# Altium schematic sheet [01] - SiPM Carrier.SchDoc("[01] - SiPM Carrier")
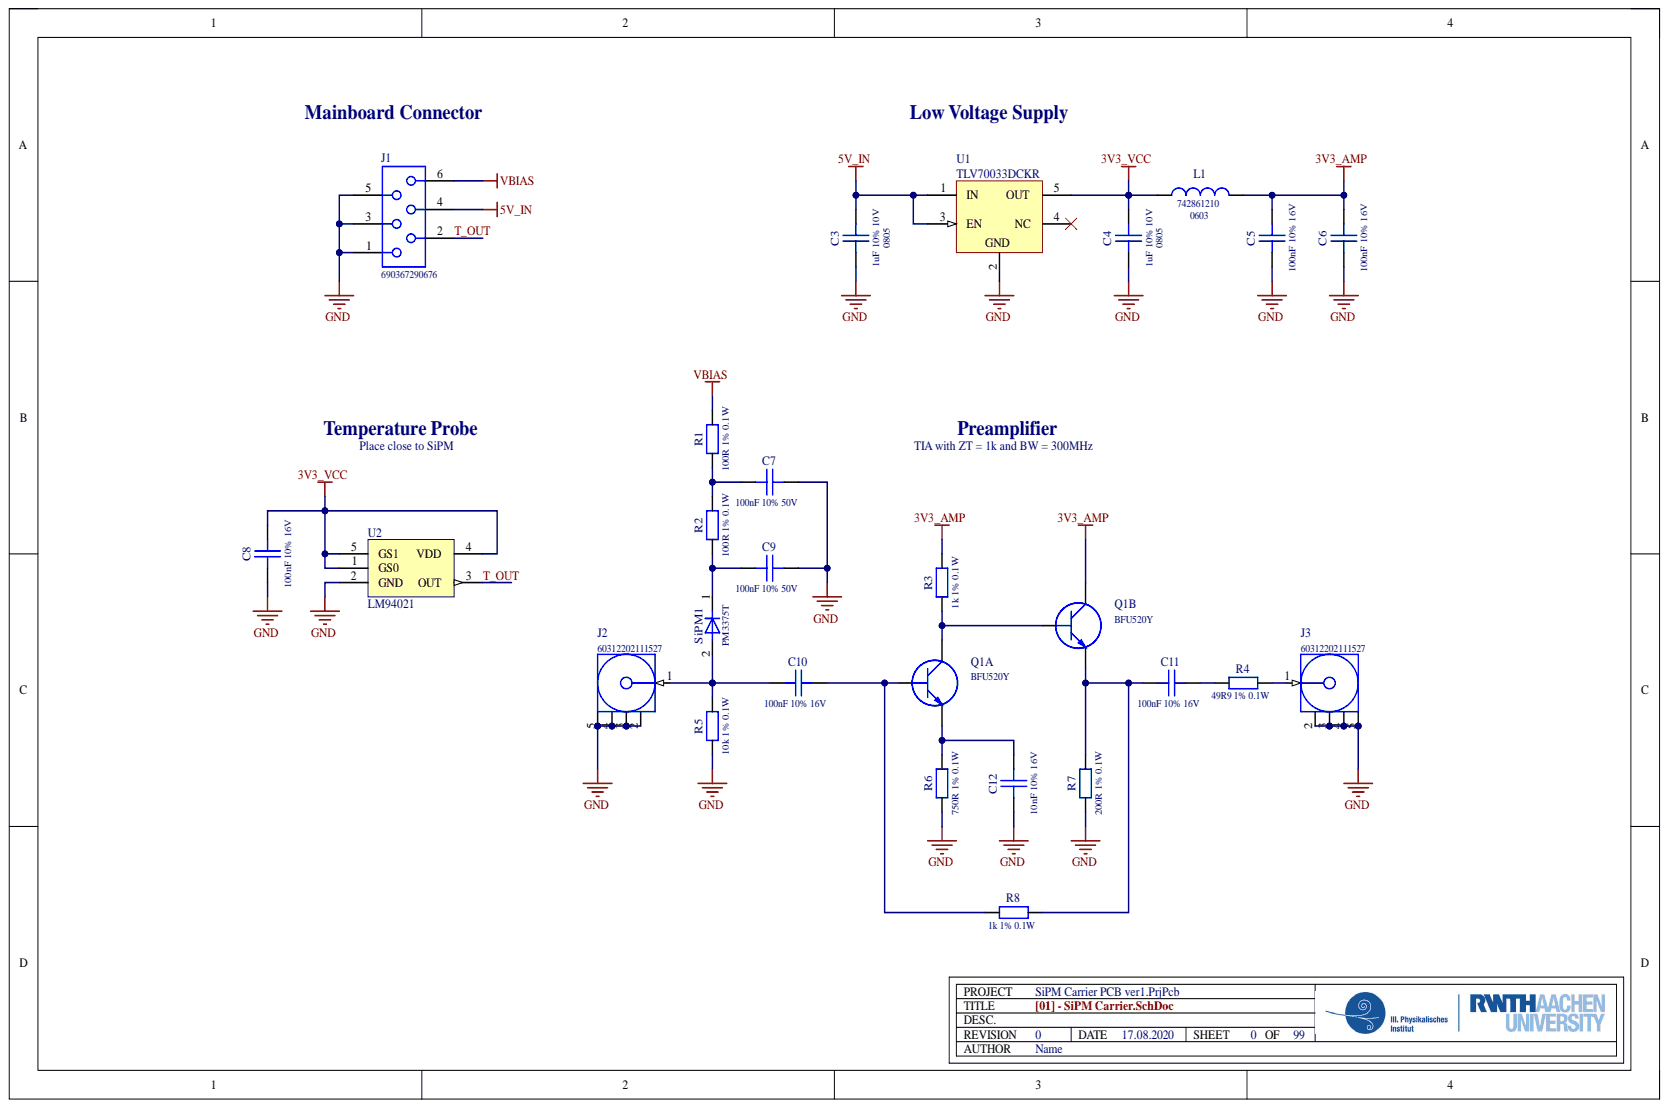
[diagram p0: [01] - SiPM Carrier.SchDoc]

## Parts
C3  1uF 10% 10V  PackageReference: 0805  [0805_CAP]
C4  1uF 10% 10V  PackageReference: 0805  [0805_CAP]
C5  100nF 10% 16V  [0603_CAP]
C6  100nF 10% 16V  [0603_CAP]
C7  100nF 10% 50V  [0603_CAP]
C8  100nF 10% 16V  [0603_CAP]
C9  100nF 10% 50V  [0603_CAP]
C10  100nF 10% 16V  [0603_CAP]
C11  100nF 10% 16V  [0603_CAP]
C12  10nF 10% 16V  [0603_CAP]
J1  690367290676  [690367290676]
J2  60312202111527  [60312202111527]
J3  60312202111527  [60312202111527]
L1  742861210  PackageReference: 0603  [0603_RES]
Q1  BFU520Y  [SOT363-6]  (parts A,B)
R1  100R 1% 0.1W  [0603_RES]
R2  100R 1% 0.1W  [0603_RES]
R3  1k 1% 0.1W  [0603_RES]
R4  49R9 1% 0.1W  [0603_RES]
R5  10k 1% 0.1W  [0603_RES]
R6  750R 1% 0.1W  [0603_RES]
R7  200R 1% 0.1W  [0603_RES]
R8  1k 1% 0.1W  [0603_RES]
SiPM1  PM3375T  [PM3375T_SMD]
U1  TLV70033DCKR  [TI-DCK5_V]
U2  LM94021  [SC70]

## Nets
3V3_AMP: C5.2 C6.2 L1.2 Q1.3 R3.1
3V3_VCC: C4.2 C8.2 L1.1 U1.5(OUT) U2.1(GS0) U2.4(VDD) U2.5(GS1)
5V_IN: C3.2 J1.4 U1.1(IN) U1.3(EN)
GND: C3.1 C4.1 C5.1 C6.1 C7.2 C8.1 C9.2 C12.1 J1.1 J1.3 J1.5 J2.2 J2.3 J2.4 J2.5 J3.2 J3.3 J3.4 J3.5 R5.2 R6.2 R7.2 U1.2 U2.2(GND)
VBIAS: J1.6 R1.1
T_OUT: J1.2 U2.3(OUT)
NetC7_1: C7.1 R1.2 R2.1
NetC9_1: C9.1 R2.2 SiPM1.1
NetC10_1: C10.1 J2.1 R5.1 SiPM1.2
NetC10_2: C10.2 Q1.1 R8.2
NetC11_1: C11.1 Q1.5 R7.1 R8.1
NetC11_2: C11.2 R4.2
NetC12_2: C12.2 Q1.2 R6.1
NetJ3_1: J3.1 R4.1
NetQ1_4: Q1.4 Q1.6 R3.2

Not connected: U1 4(NC)

## Library data of the parts
C3-C12 = Capacitor: Simple generic capacitor.; Component Kind: Standard; Library Name: CustomComponents.IntLib
  C3 C4: Value: 1uF 10% 10V
  C5 C6 C8 C10 C11: PackageReference: 0603; Value: 100nF 10% 16V
  C7 C9: PackageReference: 0603; Value: 100nF 10% 50V
  C12: PackageReference: 0603; Value: 10nF 10% 16V
J1 = 690367290676: WR-MM MiniModule Connector, Female, SMT, Vertical, pitch 2.54mm, 6pins; Contact Resistance: 10mOhm; Insulation Resistance: >1000MOhm; Pin Number: 6; Rated Current: 1.5A; Withstanding Voltage: 500VAC; Working Voltage: 100VAC; Component Kind: Standard; ComponentLink1Description: Manufacturer; ComponentLink1URL: http://www.we-online.de; ComponentLink2Description: Datasheet; ComponentLink2URL: https://katalog.we-online.de/em/datasheet/690367290676.pdf; Gender: Female; Library Name: WR-MM.IntLib; Mounting Technology: SMT; Operating Temperature: -40 to +105degC; Orientation: Vertical; Packaging: Tape and Reel; Part Number: 690367290676; Pitch: 2.54mm; Published Date: June 2019; Publisher: Wurth Elektronik
J2 J3 = 60312202111527: WR-SMA PCB End Launch Jack Round Post for 1.6mm PCB, SMT, Straight; Center Contact Diameter: 0.76mm; Connector Type: SMA; Frequency Range: DC~18GHz; Impedance: 50Ohm; Interface: MIL-STD-348; Component Kind: Standard; ComponentLink1Description: Manufacturer; ComponentLink1URL: http://www.we-online.de; ComponentLink2Description: Datasheet; ComponentLink2URL: https://katalog.we-online.com/em/datasheet/60312202111527.pdf; Gender: Jack; Library Name: WR-SMA.IntLib; Mounting Technology: SMT; Operating Temperature: -65 to +165degC; Orientation Type: Straight; Packaging: Tray; Part Number: 60312202111527; Published Date: May 2019; Publisher: Wurth Elektronik
L1 = Inductor: Simple generic inductor.; PartNumber: XXX; Component Kind: Standard; Library Name: CustomComponents.IntLib; Value: 742861210
Q1 = BFU520Y: Dual NPN wideband silicon RF transistor.; Component Kind: Standard; Library Name: CustomComponents.IntLib; PackageReference: SOT363-6; Value: BFU520Y
R1-R8 = Resistor: Simple generic resistor.; Component Kind: Standard; Library Name: CustomComponents.IntLib; PackageReference: 0603
  R1 R2: Value: 100R 1% 0.1W
  R3 R8: Value: 1k 1% 0.1W
  R4: Value: 49R9 1% 0.1W
  R5: Value: 10k 1% 0.1W
  R6: Value: 750R 1% 0.1W
  R7: Value: 200R 1% 0.1W
SiPM1 = PM3375T: Silicon Photomultiplier, 3x3mm2 - 75um type - trench - SMD housing; Component Kind: Standard; Library Name: CustomComponents.IntLib; Value: PM3375T
U1 = TLV70033DCKR: Single Output LDO, 200 mA, Fixed 3.3 V Output, 2 to 5.5 V Input, with Low IQ, 5-pin SC70 (DCK), -40 to 125 degC, Green (RoHS & no Sb/Br); Manufacturer: Texas Instruments; Accuracy(%): 2; Fixed Output Options(V): 3.3; Iout(Max)(A): 0.2; Iq(Typ)(mA): 0.03; SubFamily: Single Channel LDO; Vdo(Typ)(mV): 175; Vin(Max)(V): 5.5; Code_JEDEC: MO-203; Component Kind: Standard; ComponentLink1Description: Manufacturer URL; ComponentLink1URL: http://www.ti.com/; ComponentLink2Description: Datasheet; ComponentLink2URL: http://www.ti.com/general/docs/lit/getliterature.tsp?genericPartNumber=TLV70033&fileType=pdf; ComponentLink3Description: Package Specification; ComponentLink3URL: http://www.ti.com/litv/pdf/mpds025f; DatasheetVersion: SLVSA00D; Eco-Plan: Green (RoHS & no Sb/Br); Library Name: TI Power Management Linear Regulator Single Channel LDO.IntLib; Mounting Technology: Surface Mount; PackageDescription: 5-Pin Plastic Small-Outline, Body 2 x 1.25 mm, Pitch 0.65 mm; PackageReference: DCK5; PackageVersion: 4093553-3/G; PartNumber: TLV70033DCKR; Supplier 1: Digi-Key; Supplier 2: Digi-Key; Supplier 3: Digi-Key; Supplier 4: Newark; Supplier 5: Mouser; Supplier Part Number 1: 296-32413-2-ND; Supplier Part Number 2: 296-32413-1-ND; Supplier Part Number 3: 296-32413-6-ND; Supplier Part Number 4: 55R7467; Supplier Part Number 5: 595-TLV70033DCKR; Vin(Min)(V): 2; Vout Adj(Max)(V): 3.3; Vout Adj(Min)(V): 3.3
U2 = LM94021: Multi-Gain Analog Temperature Sensor.; Manufacturer: Texas Instruments; PartNumber: LM94021BIMG; Component Kind: Standard; Library Name: CustomComponents.IntLib; PackageReference: SC70; Value: LM94021
